# Altium schematic sheet Motor Driver.SchDoc
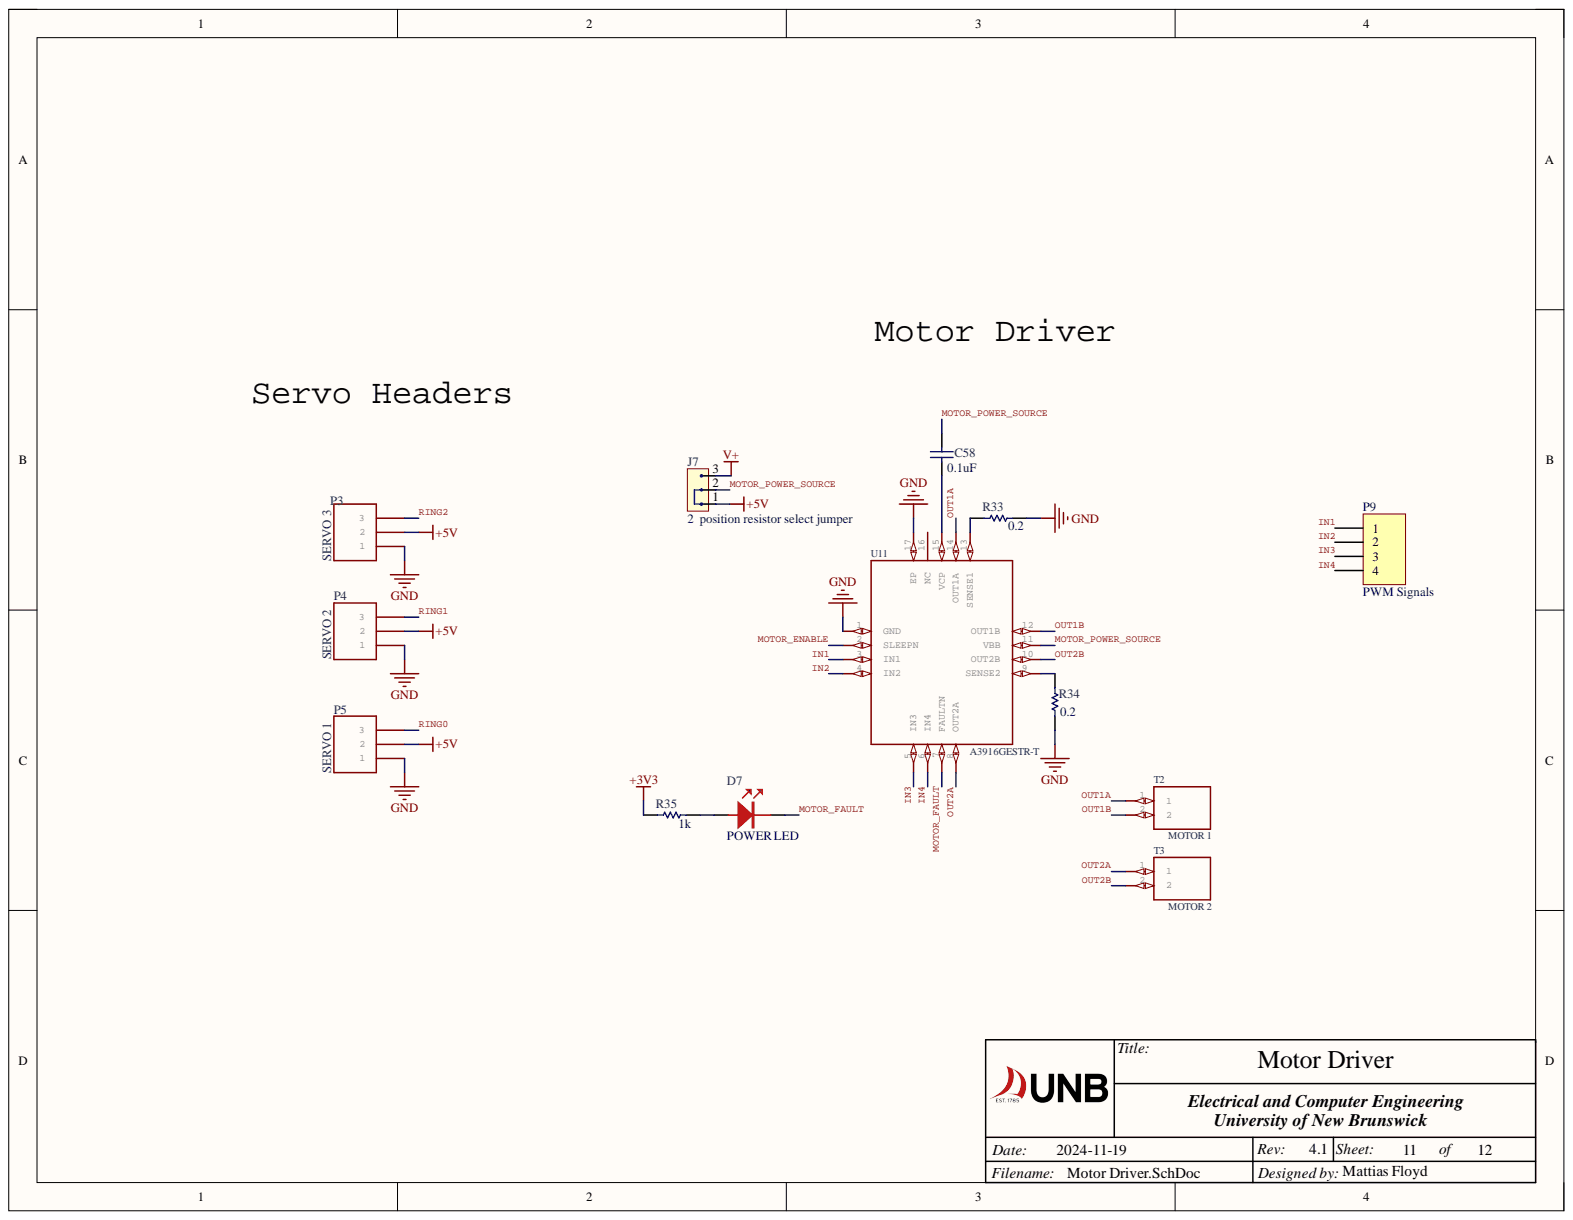
[diagram p0: Motor Driver.SchDoc]

## Parts
C58  0.1uF  [0603 Cap]
D7  POWER LED  [LED_0603_RED]
J7  2  position resistor select jumper  [0805 Res Jumper]
P3  SERVO 3  [HDR1X3]
P4  SERVO 2  [HDR1X3]
P5  SERVO 1  [HDR1X3]
P9  PWM Signals  [4 pin - 50mil Header]
R33  0.2  [6-0805_N]
R34  0.2  [6-0805_N]
R35  1k  [0603 Resistor]
T2  MOTOR 1  [SHDR2W90P0X350_1X2_800X700X840]
T3  MOTOR 2  [SHDR2W90P0X350_1X2_800X700X840]
U11  A3916GESTR-T  [QFN50P300X300X75-17N]

## Nets
+3V3: R35.1
+5V: J7.1 P3.2 P4.2 P5.2
GND: P3.1 P4.1 P5.1 R33.2 R34.1 U11.1(GND) U11.17(EP)
V+: J7.3
IN1: P9.1 U11.3(IN1)
IN2: P9.2 U11.4(IN2)
IN3: P9.3 U11.5(IN3)
IN4: P9.4 U11.6(IN4)
MOTOR_ENABLE: U11.2(SLEEPN)
MOTOR_FAULT: D7.1 U11.7(FAULTN)
MOTOR_POWER_SOURCE: C58.2 J7.2 U11.11(VBB)
OUT1A: T2.1 U11.14(OUT1A)
OUT1B: T2.2 U11.12(OUT1B)
OUT2A: T3.1 U11.8(OUT2A)
OUT2B: T3.2 U11.10(OUT2B)
RING0: P5.3
RING1: P4.3
RING2: P3.3
NetC58_1: C58.1 U11.15(VCP)
NetD7_2: D7.2 R35.2
NetR33_1: R33.1 U11.13(SENSE1)
NetR34_2: R34.2 U11.9(SENSE2)

Not connected: U11 16(NC)

## Library data of the parts
C58 = Cap Semi: Cap Ceramic 0.1uF 50VDC X7R 10% SMD 0603 Paper T/R; Manufacturer: Samsung; Manufacturer Part Number: CL10B104KB8NNNC; Capacitance: 100nF; Voltage: 50V; Case Code (Imperial): 0603; Case Code (Metric): 1608; Case/Package: 0603; Category: Ceramic Capacitors; Component Kind: Standard; ComponentLink1Description: http://www.avx.com/docs/Catalogs/cx7r.pdf; ComponentLink1URL: http://www.avx.com/docs/Catalogs/cx7r.pdf; ComponentLink2Description: https://datasheet.ciiva.com/25803/cl10b104kb8nnnc-25803393.pdf?src-supplier=Digi-Key; ComponentLink2URL: https://datasheet.ciiva.com/25803/cl10b104kb8nnnc-25803393.pdf?src-supplier=Digi-Key; ComponentLink3Description: https://datasheet.ciiva.com/26838/getdatasheetpartid-89097-26838411.pdf?src-supplier=Verical; ComponentLink3URL: https://datasheet.ciiva.com/26838/getdatasheetpartid-89097-26838411.pdf?src-supplier=Verical; ComponentLink4Description: https://datasheet.ciiva.com/29198/456651-da-01-en-vs-kondensator-0605-29198839.pdf?src-supplier=Conrad; ComponentLink4URL: https://datasheet.ciiva.com/29198/456651-da-01-en-vs-kondensator-0605-29198839.pdf?src-supplier=Conrad; Contact Plating: Tin; Depth: 0.8mm; Dielectric: X7R; Height: 0.8mm; Lead Free: Lead Free; Length: 1.6mm; Library Name: CAN_Interface_Library.SCHLIB; Material: Ceramic; Max Operating Temperature: 125°C; Min Operating Temperature: -55°C; Mount: Surface Mount; Package Quantity: 1; Packaging: Tape and Reel; Radiation Hardening: No; RoHS: Yes; Signal Integrity: Cap Semi; Simulation: CAP; Stock 1: 17879275; Supplier 1: Digi-Key; Supplier Part Number 1: 1276-1000-6-ND; Thickness: 0.035inch; Tolerance: 10%; Value: 0.1uF; Voltage Rating: 50V; Voltage Rating (DC): 50V; Width: 0.031inch
D7 = LED_0603_RED: LED, Red, 630 nm, 1.9 V, 30 mA, 60 mcd; Manufacturer: Wurth Electronics; Manufacturer Part Number: 150060SS75000; Dominant Wavelength: 630nm; Forward Current: 30mA; Forward Voltage: 1.9V; Lens Style: Clear,Rectangular; Luminous Intensity: 60mcd; Test Current: 20mA; Viewing Angle: 140°; Case Code (Imperial): 0603; Case Code (Metric): 1608; Case/Package: 0603; Category: LEDs; Color: Red; Component Kind: Standard; ComponentLink1Description: https://datasheet.ciiva.com/23843/150060ss75000-23843248.pdf?src-supplier=Newark; ComponentLink1URL: https://datasheet.ciiva.com/23843/150060ss75000-23843248.pdf?src-supplier=Newark; ComponentLink2Description: https://datasheet.ciiva.com/29279/759429-da-01-en-chipled-0603-w-kl-s-rot-150060ss75000-29279208.pdf?src-supplier=Conrad; ComponentLink2URL: https://datasheet.ciiva.com/29279/759429-da-01-en-chipled-0603-w-kl-s-rot-150060ss75000-29279208.pdf?src-supplier=Conrad; ComponentLink3Description: https://datasheet.ciiva.com/48430/150060ss75000-eng-tds-48430042.pdf?src-supplier=Distrelec; ComponentLink3URL: https://datasheet.ciiva.com/48430/150060ss75000-eng-tds-48430042.pdf?src-supplier=Distrelec; Height: 0.7mm; Length: 1.6mm; Max Operating Temperature: 85°C; Min Operating Temperature: -40°C; Mount: Surface Mount; Package Quantity: 4000; Packaging: Tape and Reel; REACH SVHC: Unknown; RoHS: Yes; Stock 1: 15937; Supplier 1: Digi-Key; Supplier Part Number 1: 732-4979-1-ND; Wavelength: 630nm; Width: 0.8mm; Library Name: bBoard for UNB Rev 4.SCHLIB
J7 = 3pad_jump: STACKPOLE ELECTRONICS - RMCF0805ZT0R00. - RES THKFLM 0805 0 OHM JUMPER 1/8W 200PPM/ C SMD ROHS COMPLIANT: YES; Max Power Dissipation: 125mW; Resistance: 0mR; Case Code (Imperial): 0805; Case Code (Metric): 2012; Case/Package: 0805; Category: Chip SMD Resistors; Component Kind: Standard; ComponentLink1Description: https://datasheet.ciiva.com/15193/getdatasheetpartid-360417-15193658.pdf?src-supplier=Verical; ComponentLink1URL: https://datasheet.ciiva.com/15193/getdatasheetpartid-360417-15193658.pdf?src-supplier=Verical; Composition: Thick Film; Contact Plating: Tin,Matte; Depth: 1.25mm; Features: Automotive AEC-Q200; Height: 0.026inch; Lead Free: Lead Free; Length: 2mm; Manufacturer: Stackpole Electronics; Manufacturer Part Number: RMCF0805ZT0R00; Max Operating Temperature: 155°C; Military Standard: Not; Min Operating Temperature: -55°C; Mount: Surface Mount; Number of Terminations: 2; Packaging: Tape and Reel; Power Rating: 125mW; Radiation Hardening: No; RoHS: Yes; Stock 1: 2734911; Supplier 1: Digi-Key; Supplier Part Number 1: RMCF0805ZT0R00CT-ND; Width: 0.049inch; Library Name: bBoard for UNB Schematic Library.SCHLIB
P3-P5 = HEADER1X31X3: METZ CONNECT - PR20203VBNN - CONNECTOR, HEADER, 3POS, 1ROW, 2.54MM; Category: Headers and Wire Housings; Component Kind: Standard; ComponentLink1Description: http://www.farnell.com/datasheets/2372088.pdf?src-supplier=Farnell; ComponentLink1URL: http://www.farnell.com/datasheets/2372088.pdf?src-supplier=Farnell; ComponentLink2Description: https://www.metz-connect.com/us/system/files/productfiles/Data_sheet_PR202XXVBNN_PR202XXVBNN_OFF-024022P.pdf?src-supplier=Digi-Key; ComponentLink2URL: https://www.metz-connect.com/us/system/files/productfiles/Data_sheet_PR202XXVBNN_PR202XXVBNN_OFF-024022P.pdf?src-supplier=Digi-Key; ComponentLink3Description: http://www.farnell.com/datasheets/2372088.pdf?src-supplier=Newark; ComponentLink3URL: http://www.farnell.com/datasheets/2372088.pdf?src-supplier=Newark; Manufacturer: METZ CONNECT; Manufacturer Part Number: PR20203VBNN; RoHS: No; Stock 1: 19824; Supplier 1: Digi-Key; Supplier Part Number 1: 1849-1003-ND; Library Name: BrilliantLabsEagleLibrary.IntLib
P9 = Header 4: Header, 4-Pin; Component Kind: Standard; Library Name: Miscellaneous Connectors.IntLib; Signal Integrity: Connector
R33 R34 = Res1: PANASONIC - ERJ-6DSFR20V - SMD Current Sense Resistor, 0.2 ohm, ERJ6DS Series, 0805 [2012 Metric], 500 mW, ± 1%, Thick Film; Max Power Dissipation: 500mW; Resistance: 200mR; Temperature Coefficient: 150ppm/°C; Case Code (Imperial): 0805; Case Code (Metric): 2012; Case/Package: 0805; Category: Chip SMD Resistors; Component Kind: Standard; ComponentLink1Description: http://www.yageo.com/documents/recent/PYu-RC_Group_51_RoHS_L_1.pdf; ComponentLink1URL: http://www.yageo.com/documents/recent/PYu-RC_Group_51_RoHS_L_1.pdf; ComponentLink2Description: https://industrial.panasonic.com/cdbs/www-data/pdf/RDN0000/AOA0000C313.pdf?src-supplier=Digi-Key; ComponentLink2URL: https://industrial.panasonic.com/cdbs/www-data/pdf/RDN0000/AOA0000C313.pdf?src-supplier=Digi-Key; ComponentLink3Description: http://www.farnell.com/datasheets/2631545.pdf?src-supplier=Newark; ComponentLink3URL: http://www.farnell.com/datasheets/2631545.pdf?src-supplier=Newark; Composition: Thick Film; Features: Automotive AEC-Q200; Height: 0.028inch; Length: 0.079inch; Library Name: CAN_Interface_Library.SCHLIB; Manufacturer: Panasonic; Manufacturer Part Number: ERJ-6DSFR20V; Max Operating Temperature: 155°C; Min Operating Temperature: -55°C; Number of Terminations: 2; Packaging: Tape and Reel; RoHS: Yes; Signal Integrity: Res; Simulation: RESISTOR; Stock 1: 4307; Supplier 1: Digi-Key; Supplier Part Number 1: P19315CT-ND; Tolerance: 1%; Value: 0.2; Width: 0.049inch
R35 = Res Semi: Res Thick Film 0603 1K Ohm 1% 0.1W(1/10W) ±100ppm/C Pad SMD Automotive T/R; Manufacturer: Stackpole Electronics; Manufacturer Part Number: RMCF0603FT1K00; Max Power Dissipation: 100mW; Resistance: 1kR; Temperature Coefficient: 100ppm/°C; Case Code (Imperial): 0603; Case Code (Metric): 1608; Case/Package: 0603; Category: Chip SMD Resistors; Component Kind: Standard; ComponentLink1Description: http://www.rohm.com/web/global/datasheet/ESR01MZPF/esr-e; ComponentLink1URL: http://www.rohm.com/web/global/datasheet/ESR01MZPF/esr-e; ComponentLink2Description: https://www.seielect.com/catalog/SEI-Packaging.pdf?src-supplier=Avnet; ComponentLink2URL: https://www.seielect.com/catalog/SEI-Packaging.pdf?src-supplier=Avnet; ComponentLink3Description: https://www.seielect.com/catalog/sei-rmcf_rmcp.pdf?src-supplier=Digi-Key; ComponentLink3URL: https://www.seielect.com/catalog/sei-rmcf_rmcp.pdf?src-supplier=Digi-Key; ComponentLink4Description: https://www.seielect.com/catalog/SEI-RMCF_RMCP.pdf?src-supplier=Avnet; ComponentLink4URL: https://www.seielect.com/catalog/SEI-RMCF_RMCP.pdf?src-supplier=Avnet; ComponentLink5Description: http://www.seielect.com/Catalog/SEI-rmc.pdf?src-supplier=Future+Electronics; ComponentLink5URL: http://www.seielect.com/Catalog/SEI-rmc.pdf?src-supplier=Future+Electronics; ComponentLink6Description: https://www.seielect.com/catalog/SEI-RMCF_RMCP.pdf?src-supplier=Avnet+Asia; ComponentLink6URL: https://www.seielect.com/catalog/SEI-RMCF_RMCP.pdf?src-supplier=Avnet+Asia; ComponentLink7Description: https://www.seielect.com/catalog/SEI-Packaging.pdf?src-supplier=Verical; ComponentLink7URL: https://www.seielect.com/catalog/SEI-Packaging.pdf?src-supplier=Verical; ComponentLink8Description: https://www.seielect.com/catalog/SEI-Packaging.pdf?src-supplier=Arrow+Electronics; ComponentLink8URL: https://www.seielect.com/catalog/SEI-Packaging.pdf?src-supplier=Arrow+Electronics; ComponentLink9Description: https://www.seielect.com/catalog/SEI-Packaging.pdf?src-supplier=Quest; ComponentLink9URL: https://www.seielect.com/catalog/SEI-Packaging.pdf?src-supplier=Quest; Composition: Thick Film; Contact Plating: Tin,Matte; Depth: 0.8mm; Features: Automotive AEC-Q200; Height: 0.022inch; Lead Free: Lead Free; Length: 1.55mm; Max Operating Temperature: 155°C; Military Standard: Not; Min Operating Temperature: -55°C; Mount: Surface Mount; Number of Terminations: 2; Packaging: Tape and Reel; Power Rating: 100mW; Radiation Hardening: No; RoHS: Yes; Signal Integrity: Res Semi; Simulation: RES; Stock 1: 4210775; Supplier 1: Digi-Key; Supplier Part Number 1: RMCF0603FT1K00CT-ND; Tolerance: 1%; Value: 1k; Width: 0.031inch; Library Name: BrillianBit.SCHLIB
T2 T3 = OSTTE020104: TERM BLK 2POS SIDE ENT 3.5MM PCB; Manufacturer: On-Shore Technology; Manufacturer Part Number: ED555/2DS; MANUFACTURER_NAME: On-Shore Technology, Inc; Color: Grey; Current: 6A; GateName_1: G$1; Number of Levels: 1; Number of Positions: 2; Pitch: 0.138inch; Plating: Steel; Voltage: 150V; Wire Gauge (Max): 28AWG; Wire Gauge (Min): 16AWG; Category: Terminal Blocks; Component Kind: Standard; ComponentLink1Description: https://datasheet.ciiva.com/823/ed555ds-823583.pdf?src-supplier=Digi-Key; ComponentLink1URL: https://datasheet.ciiva.com/823/ed555ds-823583.pdf?src-supplier=Digi-Key; Contact Material: Brass; Contact Plating: Brass; DATASHEET: https://www.on-shore.com/wp-content/uploads/OSTTEXX0104.pdf; DeviceSetName: OSTTE020104; DIGIKEY_PART_NUMBER: ED2740-ND; Flammability Rating: UL94 V-0; Height: 8.2mm; Housing Color: Grey; Housing Material: Nylon; Lead Free: Lead Free; Length: 7mm; MANUFACTURER_PART_NUMBER: OSTTE020104; Max Operating Temperature: 105°C; Min Operating Temperature: -30°C; Mount: Through Hole; Number of Pins: 2; Number of Positions Per Level: 2; Number of Rows: 1; Packaging: Bulk; RoHS: Yes; Stock 1: 24119; Supplier 1: Digi-Key; Supplier Part Number 1: ED1514-ND; SymbolName_1: OSTTE020104; Termination: Screw; Width: 6.2mm; Library Name: BrilliantLabsEagleLibrary.IntLib; LibraryName: BrilliantLabsEagleLibrary
U11 = A3916GESTR-T: ALLEGRO MICROSYSTEMS - A3916GESTR-T - Motor Driver/Controller, Stepper, 1 Output, 2.7 V to 15 V Supply, 15 V/1 A Out, QFN-16; Interface: Parallel; Logic Function: AND; Output Current: 1A; Category: Integrated Circuits (ICs); Component Kind: Standard; ComponentLink1Description: http://www.farnell.com/datasheets/2362509.pdf?src-supplier=Farnell; ComponentLink1URL: http://www.farnell.com/datasheets/2362509.pdf?src-supplier=Farnell; ComponentLink2Description: https://www.allegromicro.com/~/media/Files/Datasheets/A3916-Datasheet.ashx?src-supplier=Digi-Key; ComponentLink2URL: https://www.allegromicro.com/~/media/Files/Datasheets/A3916-Datasheet.ashx?src-supplier=Digi-Key; ComponentLink3Description: http://www.farnell.com/datasheets/2362509.pdf?src-supplier=Newark; ComponentLink3URL: http://www.farnell.com/datasheets/2362509.pdf?src-supplier=Newark; Manufacturer: Allegro MicroSystems; Manufacturer Part Number: A3916GESTR-T; Max Operating Temperature: 150°C; Min Operating Temperature: -40°C; Mount: Surface Mount; Packaging: Cut Tape; RoHS: No; Stock 1: 25170; Supplier 1: Digi-Key; Supplier Part Number 1: 620-1833-1-ND; Library Name: BrilliantLabsEagleLibrary.IntLib
